# Altium schematic sheet SoM-Supply.SchDoc(SoM-Supply)
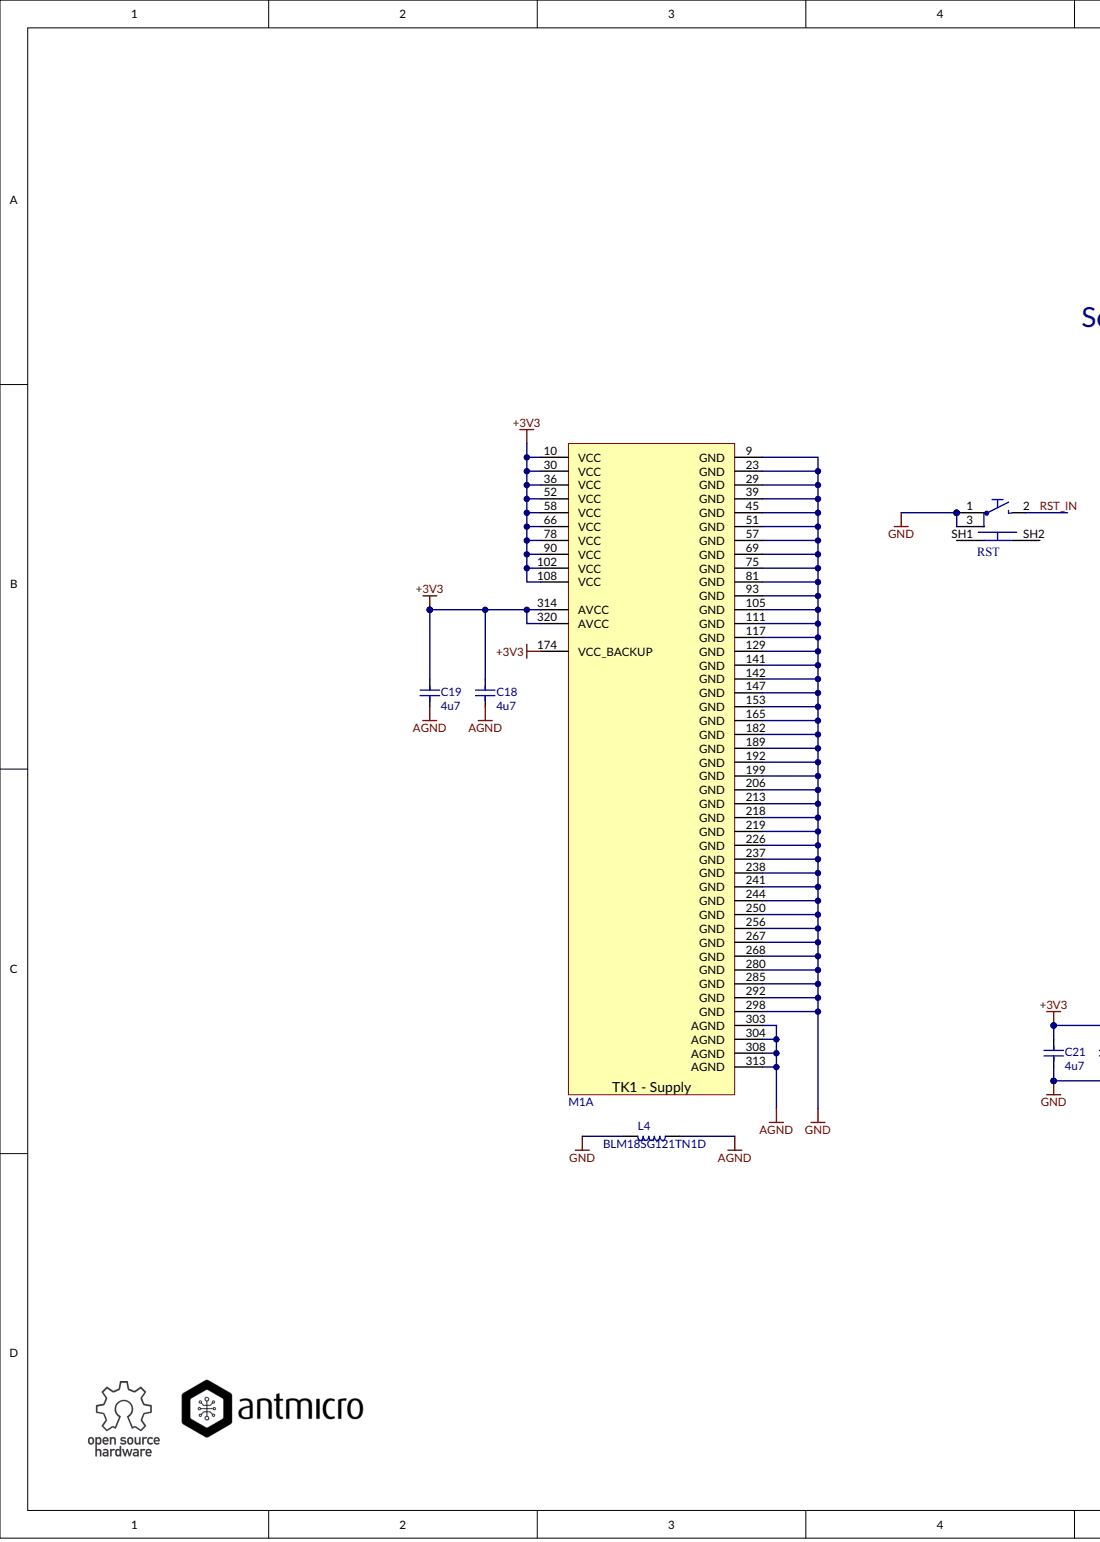
[diagram p0: SoM-Supply.SchDoc, left]
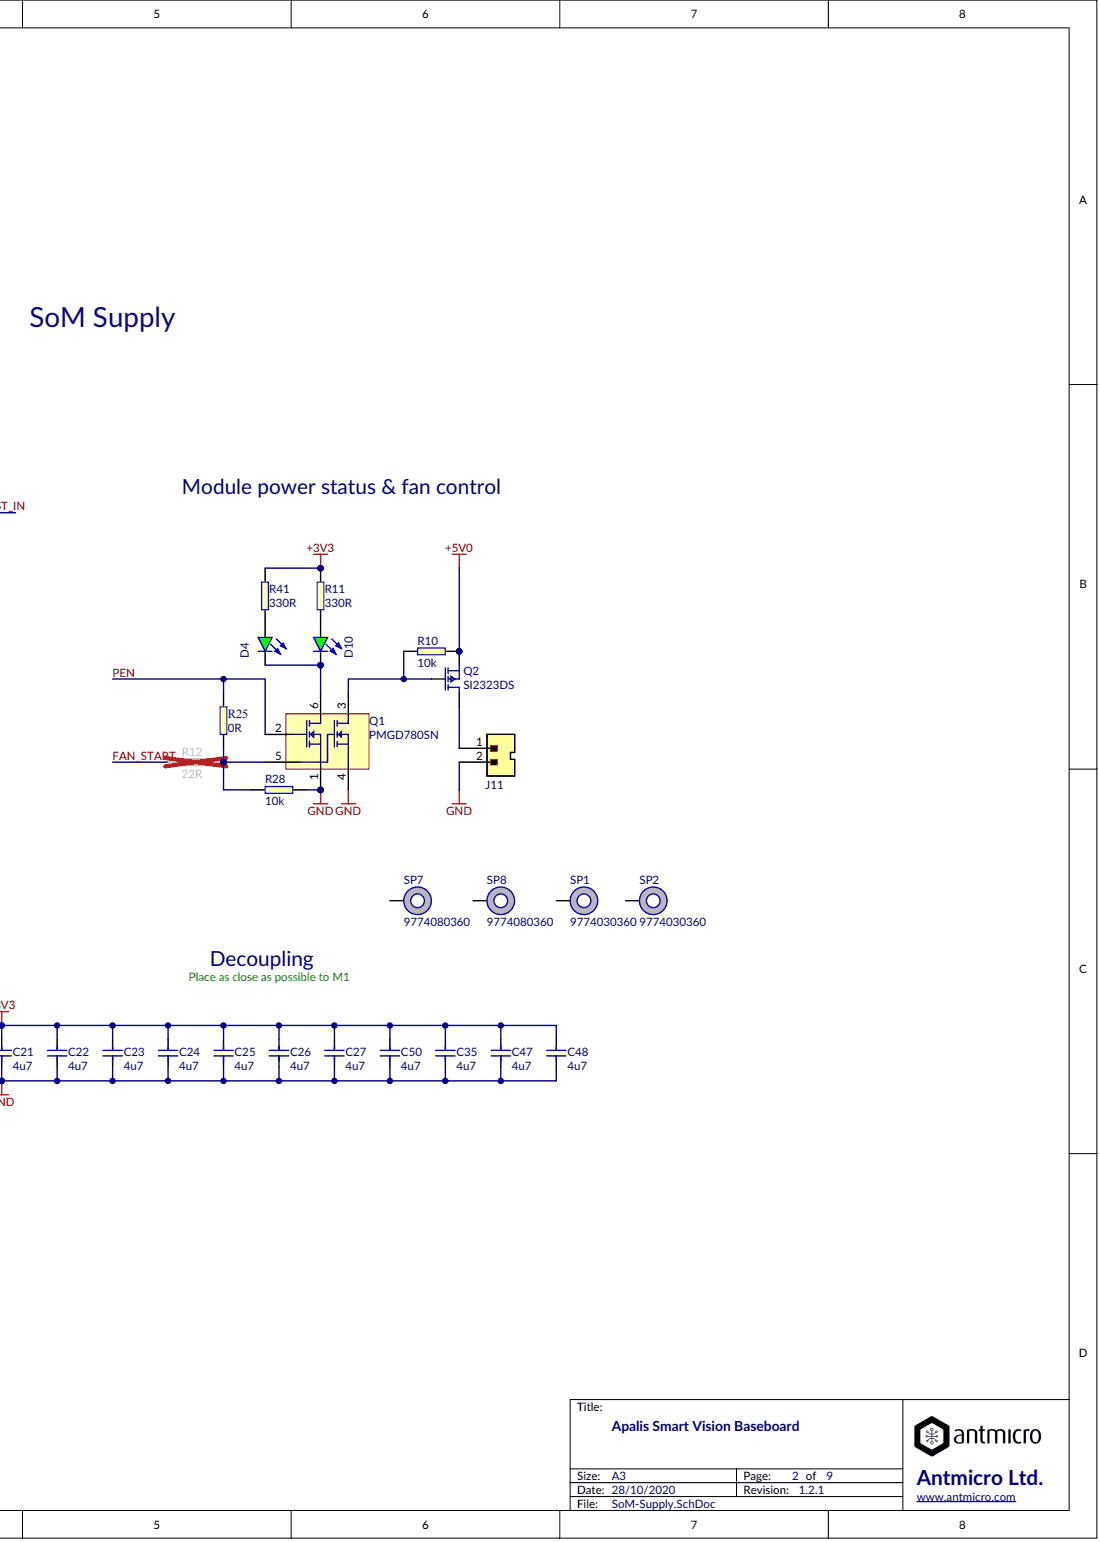
[diagram p1: SoM-Supply.SchDoc, right]

## Parts
C18  4u7
C19  4u7
C21  4u7
C22  4u7
C23  4u7
C24  4u7
C25  4u7
C26  4u7
C27  4u7
C35  4u7
C47  4u7
C48  4u7
C50  4u7
D4  KPT-1608SGC  (value not drawn)
D10  KPT-1608SGC  (value not drawn)
J11  MX-22-23-2021  (value not drawn)
L4  BLM18SG121TN1D
M1  MM70-314-310B1  (value not drawn)  (part A)
Q1  PMGD780SN
Q2  SI2323DS
R10  10k
R11  330R
R12  22R
R25  0R
R28  10k
R41  330R
RST  TL3340AF160QG  (value not drawn)
SP1  9774030360
SP2  9774030360
SP7  9774080360
SP8  9774080360

## Nets
+3V3: C18.2 C19.2 C21.2 C22.2 C23.2 C24.2 C25.2 C26.2 C27.2 C35.2 C47.2 C48.2 C50.2 M1.10(VCC) M1.30(VCC) M1.36(VCC) M1.52(VCC) M1.58(VCC) M1.66(VCC) M1.78(VCC) M1.90(VCC) M1.102(VCC) M1.108(VCC) M1.174(VCC_BACKUP) M1.314(AVCC) M1.320(AVCC) R11.2 R41.2
+5V0: Q2.2 R10.2
AGND: C18.1 C19.1 L4.2 M1.303(AGND) M1.304(AGND) M1.308(AGND) M1.313(AGND)
GND: C21.1 C22.1 C23.1 C24.1 C25.1 C26.1 C27.1 C35.1 C47.1 C48.1 C50.1 J11.2 L4.1 M1.9(GND) M1.23(GND) M1.29(GND) M1.39(GND) M1.45(GND) M1.51(GND) M1.57(GND) M1.69(GND) M1.75(GND) M1.81(GND) M1.93(GND) M1.105(GND) M1.111(GND) M1.117(GND) M1.129(GND) M1.141(GND) M1.142(GND) M1.147(GND) M1.153(GND) M1.165(GND) M1.182(GND) M1.189(GND) M1.192(GND) M1.199(GND) M1.206(GND) M1.213(GND) M1.218(GND) M1.219(GND) M1.226(GND) M1.237(GND) M1.238(GND) M1.241(GND) M1.244(GND) M1.250(GND) M1.256(GND) M1.267(GND) M1.268(GND) M1.280(GND) M1.285(GND) M1.292(GND) M1.298(GND) Q1.1 Q1.4 R28.2 RST.1 RST.3
FAN_START: R12.1
PEN: Q1.2 R25.2
RST_IN: RST.2
NetD4_A: D4.A R41.1
NetD4_K: D4.K D10.K Q1.6
NetD10_A: D10.A R11.1
NetJ11_1: J11.1 Q2.3
NetQ1_3: Q1.3 Q2.1 R10.1
NetQ1_5: Q1.5 R12.2 R25.1 R28.1

Not connected: RST SH1 SH2; SP1 1; SP2 1; SP7 1; SP8 1

## Library data of the parts
C18 C19 C21-C27 C35 C47 C48 C50 = (no symbol name): Manufacturer: MULTICOMP; Part Number: C_4u7_0603; Part Symbol: MC0603X475K6R3CT; Value: 4u7; MPN: MC0603X475K6R3CT
D4 D10 = (no symbol name): Part Number: LED_G; Manufacturer: KINGBRIGHT; Value: KPT-1608SGC; Part Symbol: KPT-1608SGC; MPN: KPT-1608SGC
J11 = (no symbol name): Part Number: molex_2223-2021; Manufacturer: Molex; Part Symbol: 022232021 A-6373-02A222; Value: MX-22-23-2021; MPN: 022232021 A-6373-02A222
L4 = (no symbol name): Manufacturer: MURATA; Part Number: BLM18SG121TN1D; Value: BLM18SG121TN1D; Part Symbol: BLM18SG121TN1D; MPN: BLM18SG121TN1D
M1 = (no symbol name): Part Number: Apalis-TK1-H; Manufacturer: JAE Electronics; Part Symbol: MM70-314-310B1; Value: MM70-314-310B1; MPN: MM70-314-310B1
Q1 = (no symbol name): Manufacturer: NXP; Part Symbol: PMGD780SN,115; Part Number: PMGD780SN; Value: PMGD780SN; MPN: PMGD780SN,115
Q2 = (no symbol name): Manufacturer: Vishay; Part Symbol: SI2323DS-T1-E3; Part Number: SI2323DS; Value: SI2323DS; MPN: SI2323DS-T1-E3
R10 R28 = (no symbol name): Manufacturer: MULTICOMP; Part Number: R_10k_0402; Part Symbol: MCMR04X1002FTL; Value: 10k; MPN: MCMR04X1002FTL
R11 R41 = (no symbol name): Manufacturer: MULTICOMP; Part Number: R_330R_0402; Part Symbol: MCMR04X3300FTL; Value: 330R; MPN: MCMR04X3300FTL
R12 = (no symbol name): Manufacturer: VISHAY; Part Number: R_22R_0402; Part Symbol: CRCW040222R0FKED; Value: 22R; MPN: CRCW040222R0FKED
R25 = (no symbol name): Manufacturer: Panasonic; Part Number: R_0R_0402; Part Symbol: ERJ2GE0R00X; Value: 0R; MPN: ERJ2GE0R00X
RST = (no symbol name): Manufacturer: E-SWITCH; Part Number: TL3340AF160QG; Value: TL3340AF160QG; Part Symbol: TL3340AF160QG; MPN: TL3340AF160QG
SP1 SP2 = (no symbol name): Part Number: WE_9774030360; Manufacturer: WURTH ELEKTRONIK; Value: 9774030360; Part Symbol: 9774030360; MPN: 9774030360
SP7 SP8 = (no symbol name): Manufacturer: WURTH ELEKTRONIK; Part Number: 9774080360; Value: 9774080360; Part Symbol: 9774080360; MPN: 9774080360
